# Altium schematic sheet [03] - POWER DIAGRAM.SchDoc
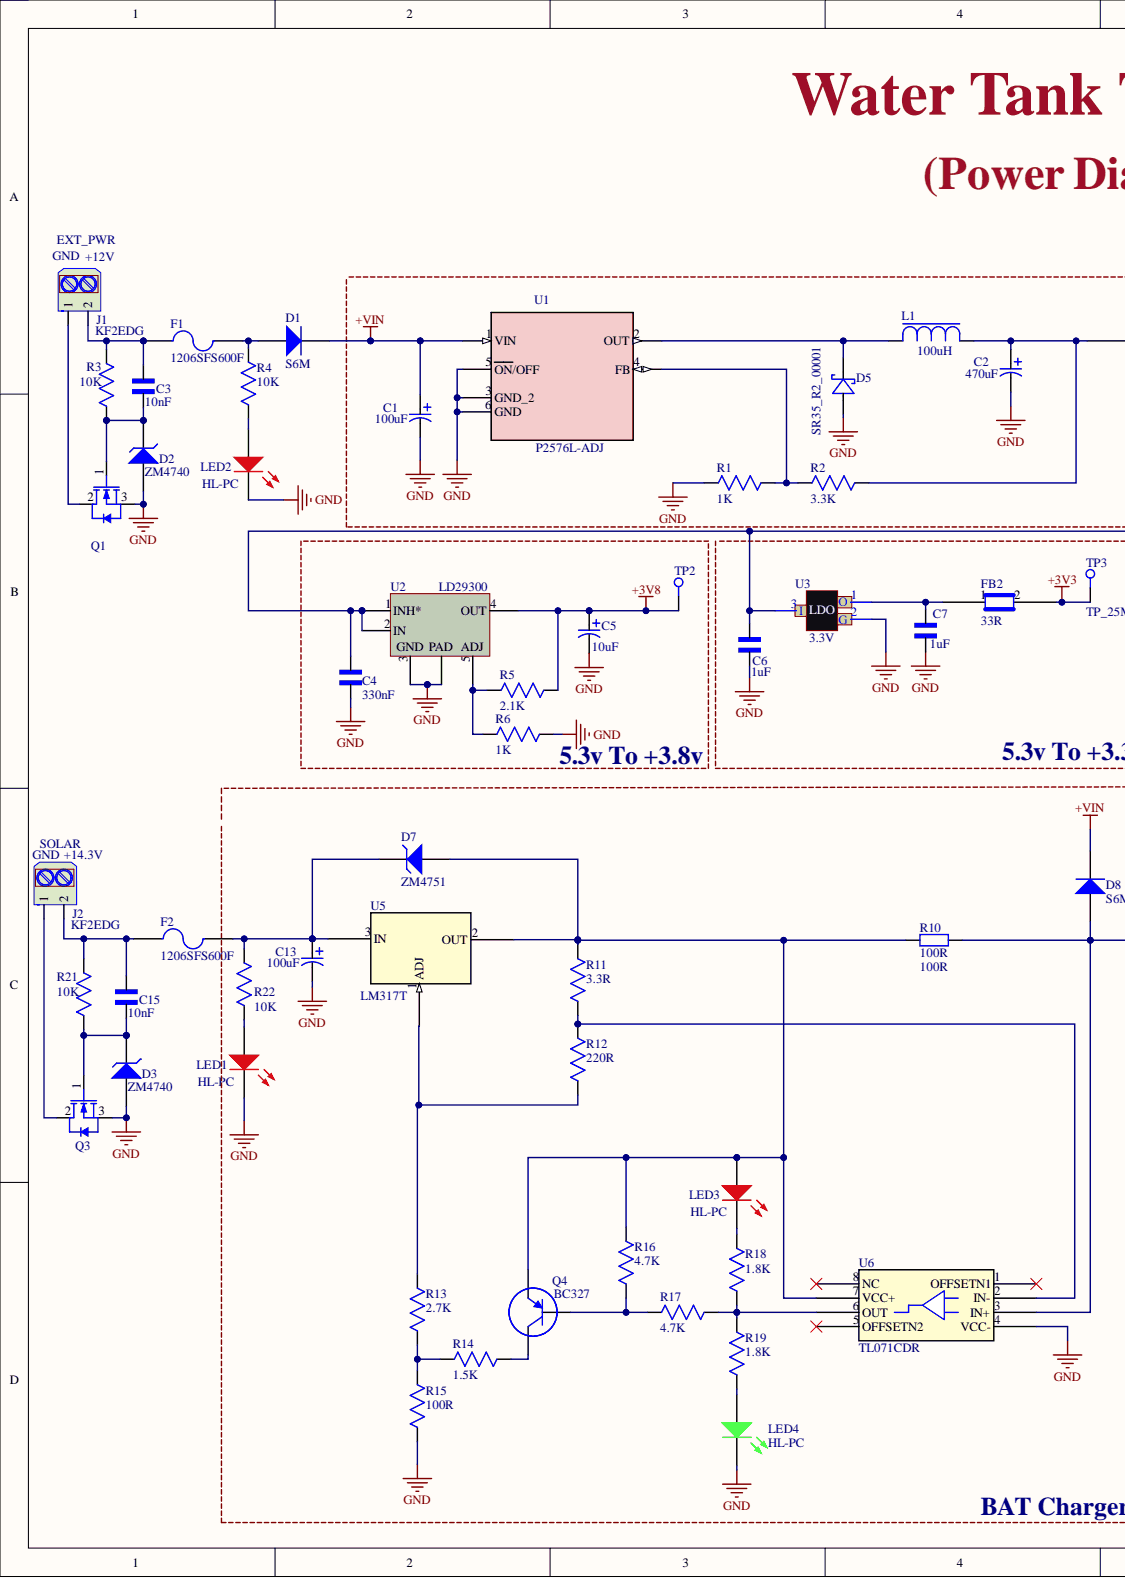
[diagram p0: [03] - POWER DIAGRAM.SchDoc, left]
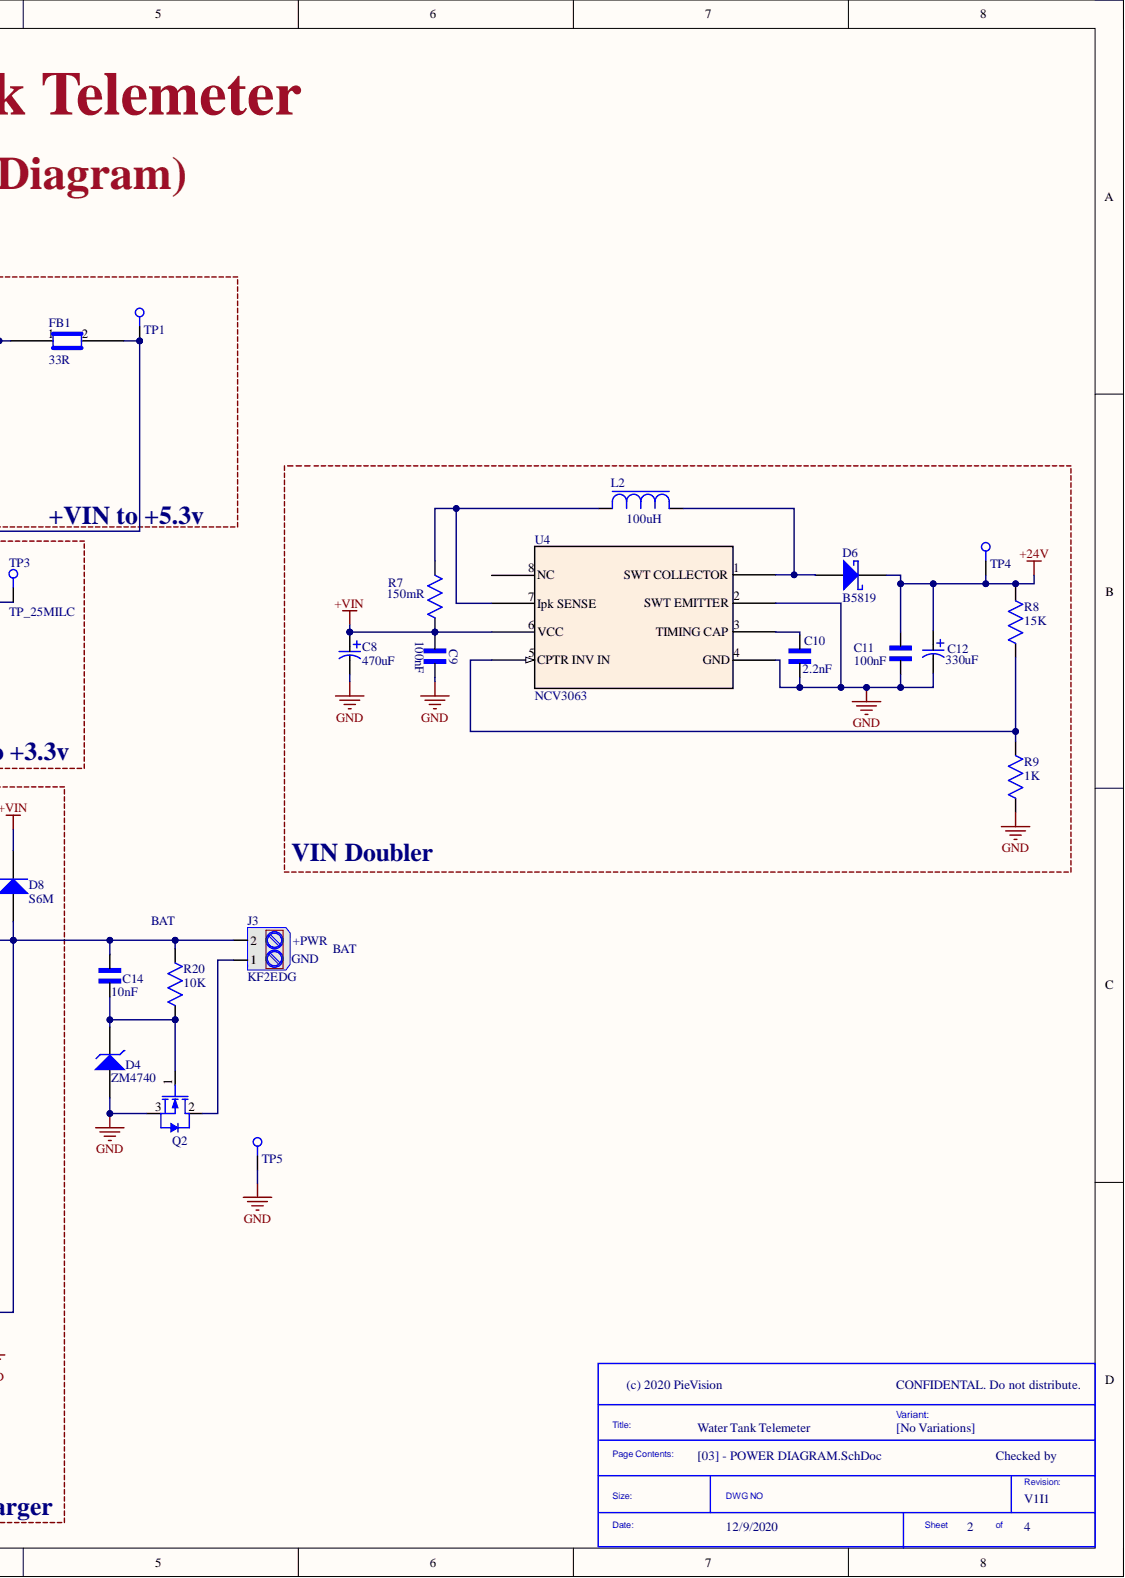
[diagram p1: [03] - POWER DIAGRAM.SchDoc, right]

## Parts
C1  100uF  [CAP_Al_1010]
C2  470uF  [CAP_Al_1010]
C3  10nF  [CAP_0805]
C4  330nF  [CAP_0805]
C5  10uF  [CAP_AL_0553]
C6  1uF  [CAP_0805]
C7  1uF  [CAP_0805]
C8  470uF  [CAP_Al_1010]
C9  100nF  [CAP_0805]
C10  2.2nF  [CAP_0805]
C11  100nF  [CAP_0805]
C12  330uF  [CAP_AL_12d5X12d5]
C13  100uF  [CAP_Al_1010]
C14  10nF  [CAP_0805]
C15  10nF  [CAP_0805]
D1  S6M  [DO-214AB]
D2  ZM4740  [MELF]
D3  ZM4740  [MELF]
D4  ZM4740  [MELF]
D5  SR35_R2_00001  [DO214AA]
D6  B5819  [SOD123]
D7  ZM4751  [MELF]
D8  S6M  [DO-214AB]
F1  1206SFS600F  [FUS_1206]
F2  1206SFS600F  [FUS_1206]
FB1  33R  [FB_0603]
FB2  33R  [FB_0603]
J1  KF2EDG  [TERM_BLK_2P_F]
J2  KF2EDG  [TERM_BLK_2P_F]
J3  KF2EDG  [TERM_BLK_2P_F]
L1  100uH  [IND_1212]
L2  100uH  [IND_1212]
LED1  HL-PC  [LED_RED_1206]
LED2  HL-PC  [LED_RED_1206]
LED3  HL-PC  [LED_RED_1206]
LED4  HL-PC  [LED_GREEN_1206]
Q1  NDT3055L  [SOT223]  (value not drawn)
Q2  NDT3055L  [SOT223]  (value not drawn)
Q3  NDT3055L  [SOT223]  (value not drawn)
Q4  BC327  [TO-92]
R1  1K  [RES_0805]
R2  3.3K  [RES_0805]
R3  10K  [RES_0805]
R4  10K  [RES_0805]
R5  2.1K  [RES_0805]
R6  1K  [RES_0805]
R7  150mR  [RES_2512]
R8  15K  [RES_0805]
R9  1K  [RES_1206]
R10  100R  [RES_AXL_22X9]
R11  3.3R  [RES_0805]
R12  220R  [RES_0805]
R13  2.7K  [RES_0805]
R14  1.5K  [RES_0805]
R15  100R  [RES_0805]
R16  4.7K  [RES_0805]
R17  4.7K  [RES_0805]
R18  1.8K  [RES_0805]
R19  1.8K  [RES_0805]
R20  10K  [RES_0805]
R21  10K  [RES_0805]
R22  10K  [RES_0805]
TP1  TP_25MILC  [TP]  (no BOM)
TP2  TP_25MILC  [TP]  (no BOM)
TP3  TP_25MILC  [TP]  (no BOM)
TP4  TP_25MILC  [TP]  (no BOM)
TP5  TP_25MILC  [TP]  (no BOM)
U1  P2576L-ADJ  [TO170P1435X465-6N]
U2  LD29300  [D2PAK/A]
U3  3.3V  [SOT-23]
U4  NCV3063  [SOIC-8]
U5  LM317T  [TO-220AB]
U6  TL071CDR  [SOIC-8]

## Nets
+3V3: FB2.2 TP3.1
+3V8: C5.1 R5.1 TP2.1 U2.4(OUT)
+24V: C11.1 C12.1 D6.1 R8.1 TP4.1
+VIN: C1.1 C8.1 C9.1 D1.1 D8.1 R7.1 U1.1(VIN) U4.6(VCC)
GND: C1.2 C2.2 C4.1 C5.2 C6.1 C7.1 C8.2 C9.2 C10.2 C11.2 C12.2 C13.2 D2.2 D3.2 D4.2 D5.2 LED1.1 LED2.1 LED4.1 Q1.3 Q2.3 Q3.3 R1.2 R6.2 R9.1 R15.2 TP5.1 U1.3(GND_2) U1.5(ON/OFF) U1.6(GND) U2.3 U2.PAD U3.2 U4.2(SWT_EMITTER) U4.4(GND) U6.4(VCC-)
NetC2_1: C2.1 FB1.1 L1.1 R2.1
NetC3_1: C3.1 D2.1 Q1.1 R3.2
NetC3_2: C3.2 F1.2 J1.2 R3.1
NetC4_2: C4.2 C6.2 FB1.2 TP1.1 U2.1(INH*) U2.2(IN) U3.3
NetC7_2: C7.2 FB2.1 U3.1
NetC10_1: C10.1 U4.3(TIMING_CAP)
NetC13_1: C13.1 D7.1 F2.1 R22.1 U5.3
NetC14_1: C14.1 D4.1 Q2.1 R20.2
NetC14_2: C14.2 D8.2 J3.2 R10.2 R20.1 U6.3(IN+)
NetC15_1: C15.1 D3.1 Q3.1 R21.2
NetC15_2: C15.2 F2.2 J2.2 R21.1
NetD1_2: D1.2 F1.1 R4.1
NetD5_1: D5.1 L1.2 U1.2(OUT)
NetD6_2: D6.2 L2.1 U4.1(SWT_COLLECTOR)
NetD7_2: D7.2 LED3.2 Q4.3 R10.1 R11.1 R16.1 U5.2 U6.7(VCC+)
NetJ1_1: J1.1 Q1.2
NetJ2_1: J2.1 Q3.2
NetJ3_1: J3.1 Q2.2
NetL2_2: L2.2 R7.2 U4.7(Ipk_SENSE)
NetLED1_2: LED1.2 R22.2
NetLED2_2: LED2.2 R4.2
NetLED3_1: LED3.1 R18.1
NetLED4_2: LED4.2 R19.2
NetQ4_1: Q4.1 R14.1
NetQ4_2: Q4.2 R16.2 R17.2
NetR1_1: R1.1 R2.2 U1.4(FB)
NetR5_2: R5.2 R6.1 U2.5
NetR8_2: R8.2 R9.2 U4.5(CPTR_INV_IN)
NetR11_2: R11.2 R12.1 U6.2(IN-)
NetR12_2: R12.2 R13.1 U5.1
NetR13_2: R13.2 R14.2 R15.1
NetR17_1: R17.1 R18.2 R19.1 U6.6(OUT)

Not connected: U4 8(NC); U6 1(OFFSETN1) 5(OFFSETN2) 8(NC)

## Library data of the parts
C1 C13 = CAP_AL_1010: CAP.EL.100uF 63V 10x10 105C 5000Hrs SMD; .Checked PCB: CHK; Product Name: BXJ63VC100MJ10TP; Component Kind: Standard; Dimensions (mm) DxL: 10.00x10.00; Product Description: CAP.EL.100uF 63V 10x10 105C 5000Hrs SMD; Value: 100uF; .Checked SCH: CHK; Library Name: tracking system schematic library.SchLib
C2 = CAP_AL_1010: CAP.EL.470uF 25V 10x10 105C 2000Hrs SMD ±20%; .Checked PCB: CHK; Product Name: BDS25VC470MJ10TP; Component Kind: Standard; Dimensions (mm) DxL: 10.00x10.00; Product Description: CAP.EL.470uF 25V 10x10 105C 2000Hrs SMD ±20%; Value: 470uF; .Checked SCH: CHK; Library Name: tracking system schematic library.SchLib
C3 C14 = CAP_0805: .Checked PCB: CHK; Product Description: MLCC (2012) 0805 10nF 50VDC ±5% C0G (NP0); Product Name: CL21C103JBFNNNE; Component Kind: Standard; Package: 0805; Value: 10nF; .Checked SCH: CHK; Library Name: Water Tank Telemeter.SchLib
C4 = CAP_0805: MLCC (2012) 0805 330nF 16VDC ±10% X7R; Manufacturer: SAMSUNG; .Checked PCB: CHK; Product Name: CL21B334KOCNNNC; Component Kind: Standard; Package / Case: 0805; Product Description: MLCC (2012) 0805 330nF 16VDC ±10% X7R; Value: 330nF; .Checked SCH: CHK; Library Name: tracking system schematic library.SchLib
C5 = CAP_AL_0553: CAP.EL.10uF 35V 5x5.3 85C SMD SAMWHA; .Checked PCB: CHK; Product Name: SC1V106M05005VR; Component Kind: Standard; Manufacturer: SAMWHA; Product Description: CAP.EL.10uF 35V 5x5.3 85C SMD SAMWHA; Value: 10uF; .Checked SCH: CHK; Library Name: tracking system schematic library.SchLib
C6 C7 = CAP_0805: .Checked PCB: CHK; Product Description: MLCC (2012) 0805 1uF 50VDC -20% +80% Y5V; Product Name: CL21F105ZBFNNNE; Component Kind: Standard; Package: 0805; Value: 1uF; .Checked SCH: CHK; Library Name: Water Tank Telemeter.SchLib
C8 = CAP_AL_1010: CAP.EL.470uF 25V 10x10 105C 2000Hrs SMD; .Checked PCB: CHK; Product Name: BDS25VC470MJ10TP; Component Kind: Standard; Dimensions (mm) DxL: 10.00x10.00; Product Description: CAP.EL.470uF 25V 10x10 105C 2000Hrs SMD; Value: 470uF; .Checked SCH: CHK; Library Name: Water Tank Telemeter.SchLib
C9 C11 = CAP_0805: .Checked PCB: CHK; Product Description: MLCC (2012) 0805 100nF 50VDC ±5% X7R; Product Name: CL21B104JBCNNNC; Component Kind: Standard; Package: 0805; Value: 100nF; .Checked SCH: CHK; Library Name: Water Tank Telemeter.SchLib
C10 = CAP_0805: MLCC (2012) 0805 2.2nF 50VDC ±5% X7R; .Checked PCB: CHK; Product Name: CL21B222JBANNNC; Component Kind: Standard; Package: 0805; Product Description: MLCC (2012) 0805 2.2nF 50VDC ±5% X7R; Value: 2.2nF; .Checked SCH: CHK; Library Name: Water Tank Telemeter.SchLib
C12 = CAP_AL_1212: CAP.EL.330uF 50V 12.5x13.5 85C SMD SAMWHA; .Checked PCB: CHK; Product Name: SC1H337M1213MVR; Component Kind: Standard; Dimensions (mm) DxL: 12.5X12.5; Product Description: CAP.EL.330uF 50V 12.5x13.5 85C SMD SAMWHA; Value: 330uF; .Checked SCH: CHK; Library Name: Water Tank Telemeter.SchLib
C15 = CAP_0805: .Checked PCB: .; Product Description: MLCC (2012) 0805 10nF 50VDC ±5% C0G (NP0); Product Name: CL21C103JBFNNNE; .Checked SCH: .; Component Kind: Standard; Package: 0805; Value: 10nF; Library Name: Water Tank Telemeter.SchLib
D1 D8 = DID_DO214: DIODE 6A 1000V SMT DO214AB (SMC); .Checked PCB: CHK; Product Name: S6M-DC; Component Kind: Standard; Package: DO214AB; Product Description: DIODE 6A 1000V SMT DO214AB (SMC); Value: S6M; .Checked SCH: CHK; Library Name: Water Tank Telemeter.SchLib
D2 D3 = DID_ZEN_MELF: DIODE ZENER 1W 10V ±5% LL-41 (MELF) SMT; .Checked PCB: .; Product Name: ZM4740A-HT; .Checked SCH: .; Component Kind: Standard; Package: MELF; Product Description: DIODE ZENER 1W 10V ±5% LL-41 (MELF) SMT; Value: ZM4740; Library Name: Water Tank Telemeter.SchLib
D4 = DID_ZEN_MELF: DIODE ZENER 1W 10V ±5% LL-41 (MELF) SMT; .Checked PCB: CHK; Product Name: ZM4740A-HT; Component Kind: Standard; Package: MELF; Product Description: DIODE ZENER 1W 10V ±5% LL-41 (MELF) SMT; Value: ZM4740; .Checked SCH: CHK; Library Name: Water Tank Telemeter.SchLib
D5 = D_SCK_DO214AA: Product Description; Manufacturer: PANJIT; .Checked PCB: CHK; Product Description: DIODE SCHOTTKY 3A 50V DO214AA SMB PANJIT; Component Kind: Standard; Package / Case: DO214AA; Product Name: SR35_R2_00001; .Checked SCH: CHK; Library Name: tracking system schematic library.SchLib
D6 = DID_SCHK_SOD123: DIODE SCHOTTKY 1A 40V SOD123; .Checked PCB: CHK; Product Name: B5819W; Component Kind: Standard; Package: SOD123; Product Description: DIODE SCHOTTKY 1A 40V SOD123; Value: B5819; .Checked SCH: CHK; Library Name: Water Tank Telemeter.SchLib
D7 = DID_ZEN_MELF: DIODE ZENER 1W 30V ±5% LL-41 (MELF) SMT; .Checked PCB: .; Product Name: ZM4751A-HT; .Checked SCH: .; Component Kind: Standard; Package: MELF; Product Description: DIODE ZENER 1W 30V ±5% LL-41 (MELF) SMT; Value: ZM4751; Library Name: Water Tank Telemeter.SchLib
F1 = FUZ_SMD_1206: FUSE BOARD MOUNT 6A 24VDC 1206; .Checked PCB: CHK; Product Name: 1206SFS600F/24-2; Component Kind: Standard; Package: 1206; Product Description: FUSE BOARD MOUNT 6A 24VDC 1206; Value: 1206SFS600F; .Checked SCH: CHK; Library Name: Water Tank Telemeter.SchLib
F2 = FUZ_SMD_1206: FUSE BOARD MOUNT 6A 24VDC 1206; .Checked PCB: .; Product Name: 1206SFS600F/24-2; .Checked SCH: .; Component Kind: Standard; Package: 1206; Product Description: FUSE BOARD MOUNT 6A 24VDC 1206; Value: 1206SFS600F; Library Name: Water Tank Telemeter.SchLib
FB1 FB2 = FB_0603: FERRITE BEAD 0603 33R 3A MURATA; .Checked PCB: CHK; Product Name: BLM18PG330SN1D; Component Kind: Standard; Manufacturer: MURATA; Package / Case: 0603; Product Description: FERRITE BEAD 0603 33R 3A MURATA; Value: 33R; .Checked SCH: CHK; Library Name: tracking system schematic library.SchLib
J1-J3 = TERM_BLK_2POS_F: TERM.BLK.5.08MM 2P FEMALE GREEN; Product Name: KF2EDG-5.08-2P-14; Component Kind: Standard; ComponentLink1Description: TERM.BLK.5.08MM 2P FEMALE GREEN; ComponentLink1URL: https://www.ozdisan.com/konnektor-ve-baglanti-elemanlari/klemensler/gecmeli-klemensler/KF2EDG-5-08-2P-14; Product Description: TERM.BLK.5.08MM 2P FEMALE GREEN; Value: KF2EDG; Library Name: Water Tank Telemeter.SchLib
L1 L2 = IND_1212: INDUCTOR POWER 100UH 12X12X8MM SMD; .Checked PCB: CHK; Product Name: SRI1207-101M; Component Kind: Standard; Dimensions (mm) LxWxH: 12.00x12.00x8.00; Inductance: 100uH; Product Description: INDUCTOR POWER 100UH 12X12X8MM SMD; Value: 100uH; .Checked SCH: CHK; Library Name: tracking system schematic library.SchLib
LED1 LED3 = LED_RED_1206: LED SMD 1206 RED WATER CLEAR 90-120 mcd 140C; Manufacturer: HONGLITRONIC; .Checked PCB: CHK; Product Name: HL-PC-3216S9AC; Color: RED; Component Kind: Standard; Product Description: LED SMD 1206 RED WATER CLEAR 90-120 mcd 140C; Value: HL-PC; .Checked SCH: CHK; Library Name: Water Tank Telemeter.SchLib
LED2 = LED_RED_1206: LED SMD 1206 RED WATER CLEAR 90-120 mcd 140C; Manufacturer: HONGLITRONIC; .Checked PCB: .; Product Name: HL-PC-3216S9AC; .Checked SCH: .; Color: RED; Component Kind: Standard; Product Description: LED SMD 1206 RED WATER CLEAR 90-120 mcd 140C; Value: HL-PC; Library Name: Water Tank Telemeter.SchLib
LED4 = LED_GREEN_1206: LED SMD 1206 GREEN WATER CLEAR 600-800mcd; Manufacturer: HONGLITRONIC; .Checked PCB: CHK; Product Name: HL-PC-3216U51GC; Color: GREEN; Component Kind: Standard; Product Description: LED SMD 1206 GREEN WATER CLEAR 600-800mcd; Value: HL-PC; .Checked SCH: CHK; Library Name: Water Tank Telemeter.SchLib
Q1-Q3 = MOSFET_N_SOT223: MOSFET DIS.4A 60V N-CH SOT223 ENHANCEMENT SMT; Manufacturer: ONS; .Checked PCB: CHK; Component Kind: Standard; Package / Case: SOT223; Product Description: MOSFET DIS.4A 60V N-CH SOT223 ENHANCEMENT SMT; Product Name: NDT3055L; Value: NDT3055L; .Checked SCH: CHK; Library Name: Water Tank Telemeter.SchLib
Q4 = BJT_PNP_THT_CBE: TRANSISTOR DIS.500mA 50V PNP TO92 AMP THT	TRANSISTOR DIS.500mA 50V PNP TO92 AMP THT; Product Name: BC327-40ZL1G; Component Kind: Standard; Package: TO-92; Product Description: TRANSISTOR DIS.500mA 50V PNP TO92 AMP THT	TRANSISTOR DIS.500mA 50V PNP TO92 AMP THT; Value: BC327; Library Name: Water Tank Telemeter.SchLib
R1 = RES_0805: RES.(2012) 0805 1K Ohms 1% 1/8W-S 100PPM; .Checked PCB: CHK; Product Name: 0805S8F1001T5E; Component Kind: Standard; Package / Case: 0805; Product Description: RES.(2012) 0805 1K Ohms 1% 1/8W-S 100PPM; Tolerance: ±1%; Value: 1K; Watt: 1/8W-S; .Checked SCH: CHK; Library Name: tracking system schematic library.SchLib
R2 = RES_0805: RES.(2012) 0805 3.3K Ohms 1% 1/8W-S 100PPM; .Checked PCB: CHK; Product Name: 0805S8F3301T5E; Component Kind: Standard; Package / Case: 0805; Product Description: RES.(2012) 0805 3.3K Ohms 1% 1/8W-S 100PPM; Tolerance: ±1%; Value: 3.3K; Watt: 1/8W-S; .Checked SCH: CHK; Library Name: tracking system schematic library.SchLib
R3 R22 = RES_0805: RES.(2012) 0805 10K Ohms 1% 1/8W-S 100PPM; .Checked PCB: CHK; Product Name: 0805S8F1002T5E; Component Kind: Standard; Package / Case: 0805; Product Description: RES.(2012) 0805 10K Ohms 1% 1/8W-S 100PPM; Tolerance: ±1%; Value: 10K; Watt: 1/8W-S; .Checked SCH: CHK; Library Name: tracking system schematic library.SchLib
R4 R20 R21 = RES_0805: RES.(2012) 0805 10K Ohms 1% 1/8W-S 100PPM; .Checked PCB: .; Product Name: 0805S8F1002T5E; .Checked SCH: .; Component Kind: Standard; Package / Case: 0805; Product Description: RES.(2012) 0805 10K Ohms 1% 1/8W-S 100PPM; Tolerance: ±1%; Value: 10K; Watt: 1/8W-S; Library Name: tracking system schematic library.SchLib
R5 = RES_0805: RES.(2012) 0805 2.1K Ohms 1% 1/8W-S 100PPM; .Checked PCB: CHK; Product Name: 0805S8F2101T5E; Component Kind: Standard; Package / Case: 0805; Product Description: RES.(2012) 0805 2.1K Ohms 1% 1/8W-S 100PPM; Tolerance: ±1%; Value: 2.1K; Watt: 1/8W-S; .Checked SCH: CHK; Library Name: tracking system schematic library.SchLib
R6 = RES_0805: RES.(2012) 0805 1K Ohms 5% 1/8W-S 100PPM; .Checked PCB: CHK; Product Name: 0805S8J0102T5E; Component Kind: Standard; Package / Case: 0805; Product Description: RES.(2012) 0805 1K Ohms 5% 1/8W-S 100PPM; Tolerance: ±5%; Value: 1K; Watt: 1/8W-S; .Checked SCH: CHK; Library Name: tracking system schematic library.SchLib
R7 = RES_2512: RES.(6432) 2512 150m Ohms 1% 2W 200PPM; .Checked PCB: .; Product Name: HP122WF150LT4E; .Checked SCH: .; Component Kind: Standard; Package: 2512; Product Description: RES.(6432) 2512 150m Ohms 1% 2W 200PPM; Value: 150mR; Library Name: Water Tank Telemeter.SchLib
R8 = RES_0805: RES.(2012) 0805 15K Ohms 5% 1/8W-S 100PPM; .Checked PCB: .; Product Name: 0805S8J0153T5E; .Checked SCH: .; Component Kind: Standard; Package: 0805; Product Description: RES.(2012) 0805 15K Ohms 5% 1/8W-S 100PPM; Value: 15K; Library Name: Water Tank Telemeter.SchLib
R9 = RES_1206: RES.(3216) 1206 1K Ohms 1% 1/4W-S 100PPM; .Checked PCB: 1206S4F1001T5E; Component Kind: Standard; Package / Case: 1206 (3216 Metric); Product Description: RES.(3216) 1206 1K Ohms 1% 1/4W-S 100PPM; Product Name: 1206S4F1001T5E; Tolerance: ±1%; Value: 1K; Watt: 1/4W-S; .Checked SCH: 1206S4F1001T5E; Library Name: tracking system schematic library.SchLib
R10 = RES_AXL_20X9: RES.CEMENT 5W 100 Ohms 5% THT; .Checked PCB: CHK; Product Name: PRW05WJP101B00; Component Kind: Standard; Package: AXIAL; Product Description: RES.CEMENT 5W 100 Ohms 5% THT; Value: 100R; .Checked SCH: CHK; Library Name: Water Tank Telemeter.SchLib
R11 = RES_0805: RES.(2012) 0805 3.3 Ohms 1% 1/8W +300PPM~-200PPM; .Checked PCB: CHK; Product Name: RC2012F3R3CS; Component Kind: Standard; Package / Case: 0805; Product Description: RES.(2012) 0805 3.3 Ohms 1% 1/8W +300PPM~-200PPM; Tolerance: ±1%; Value: 3.3R; Watt: 1/8W; .Checked SCH: CHK; Library Name: tracking system schematic library.SchLib
R12 = RES_0805: RES.(2012) 0805 220 Ohms 0.5% 1/2W 100PPM; .Checked PCB: CHK; Product Name: ERJ-P06D2200V; Component Kind: Standard; Package / Case: 0805; Product Description: RES.(2012) 0805 220 Ohms 0.5% 1/2W 100PPM; Tolerance: ±0.5%; Value: 220R; Watt: 1/2W; .Checked SCH: CHK; Library Name: tracking system schematic library.SchLib
R13 = RES_0805: RES.(2012) 0805 2.7K Ohms 1% 1/8W-S 100PPM; .Checked PCB: CHK; Product Name: 0805S8F2701T5E; Component Kind: Standard; Package / Case: 0805; Product Description: RES.(2012) 0805 2.7K Ohms 1% 1/8W-S 100PPM; Tolerance: ±1%; Value: 2.7K; Watt: 1/8W-S; .Checked SCH: CHK; Library Name: tracking system schematic library.SchLib
R14 = RES_0805: RES.(2012) 0805 1.5K Ohms 1% 1/8W-S 100PPM; .Checked PCB: CHK; Product Name: 0805S8F1501T5E; Component Kind: Standard; Package / Case: 0805; Product Description: RES.(2012) 0805 1.5K Ohms 1% 1/8W-S 100PPM; Tolerance: ±1%; Value: 1.5K; Watt: 1/8W-S; .Checked SCH: CHK; Library Name: tracking system schematic library.SchLib
R15 = RES_0805: RES.(2012) 0805 100 Ohms 1% 1/8W-S 200PPM; .Checked PCB: CHK; Product Name: 0805S8F1000T5E; Component Kind: Standard; Package / Case: 0805; Product Description: RES.(2012) 0805 100 Ohms 1% 1/8W-S 200PPM; Tolerance: ±1%; Value: 100R; Watt: 1/8W-S; .Checked SCH: CHK; Library Name: tracking system schematic library.SchLib
R16 R17 = RES_0805: RES.(2012) 0805 4.7K Ohms 5% 1/8W-S 100PPM; .Checked PCB: CHK; Product Name: 0805S8J0472T5E; Component Kind: Standard; Package / Case: 0805; Product Description: RES.(2012) 0805 4.7K Ohms 5% 1/8W-S 100PPM; Tolerance: ±5%; Value: 4.7K; Watt: 1/8W-S; .Checked SCH: CHK; Library Name: tracking system schematic library.SchLib
R18 R19 = RES_0805: RES.(2012) 0805 1.8K Ohms 5% 1/8W-S 100PPM; .Checked PCB: CHK; Product Name: 0805S8J0182T5E; Component Kind: Standard; Package / Case: 0805; Product Description: RES.(2012) 0805 1.8K Ohms 5% 1/8W-S 100PPM; Tolerance: ±5%; Value: 1.8K; Watt: 1/8W-S; .Checked SCH: CHK; Library Name: tracking system schematic library.SchLib
TP1 TP4 TP5 = TP_25MILC: Test Point 25mil; .Checked PCB: CHK; .Checked SCH: CHK; Library Name: tracking system schematic library.SchLib
TP2 = TP_25MILC: Test Point 25mil; .Checked PCB: .; .Checked SCH: .; Library Name: tracking system schematic library.SchLib
TP3 = TP_25MILC: Test Point 25mil; Library Name: tracking system schematic library.SchLib
U1 = P2576L-AD-TQ5-R: IC-2576 V.REG.3A ADJ SIMPL.STEP-DOWN SMD TO-263; Manufacturer: UTC; .Checked PCB: CHK; Component Kind: Standard; Package / Case: TO263-5 (D2PAK-5); Product Description: IC-2576 V.REG.3A ADJ SIMPL.STEP-DOWN SMD TO-263; Product Name: P2576L-AD-TQ5-R; .Checked SCH: CHK; Library Name: tracking system schematic library.SchLib
U2 = LD29300: IC-29300 REG. LDO. ADJ 3A P2PAK/A; Manufacturer: STM; .Checked PCB: CHK; Product Name: LD29300P2MTR; Component Kind: Standard; Package / Case: P2PAK/A; Product Description: IC-29300 REG. LDO. ADJ 3A P2PAK/A; Value: LD29300; .Checked SCH: CHK; Library Name: tracking system schematic library.SchLib
U3 = MCP1700: IC-1700 REG LDO 3.3V 0.25A SOT23-3; .Checked PCB: CHK; Product Name: MCP1700T-3302E/TT; Component Kind: Standard; Package / Case: SOT23-3; Product Description: IC-1700 REG LDO 3.3V 0.25A SOT23-3; Value: 3.3V; .Checked SCH: CHK; Library Name: tracking system schematic library.SchLib
U4 = NCV3063DR2G: IC-3063 INV. SWITCHING REGULATORS SOIC8 SMD ONS; .Checked PCB: CHK; Component Kind: Standard; Package: SOIC8; Product Description: IC-3063 INV. SWITCHING REGULATORS SOIC8 SMD ONS; Product Name: NCV3063DR2G; Value: NCV3063; .Checked SCH: CHK; Library Name: Water Tank Telemeter.SchLib
U5 = LM317T: IC-317 V.REG/STABILIZER 1.5A TO220 DOUBLE GAUG ST; Product Name: LM317T-DG; Component Kind: Standard; Package: TO220-3; Product Description: IC-317 V.REG/STABILIZER 1.5A TO220 DOUBLE GAUG ST; Value: LM317T; Library Name: Water Tank Telemeter.SchLib
U6 = TL071CDR: IC-071 OPAMP JFET 3MHZ SOIC8; Component Kind: Standard; Manufacturer: SOIC8; Package: SOIC8; Product Description: IC-071 OPAMP JFET 3MHZ SOIC8; Product Name: TL071CDR; Value: TL071CDR; Library Name: Water Tank Telemeter.SchLib
